# Altium schematic sheet 02 - Power CSI and Bank Power.SchDoc
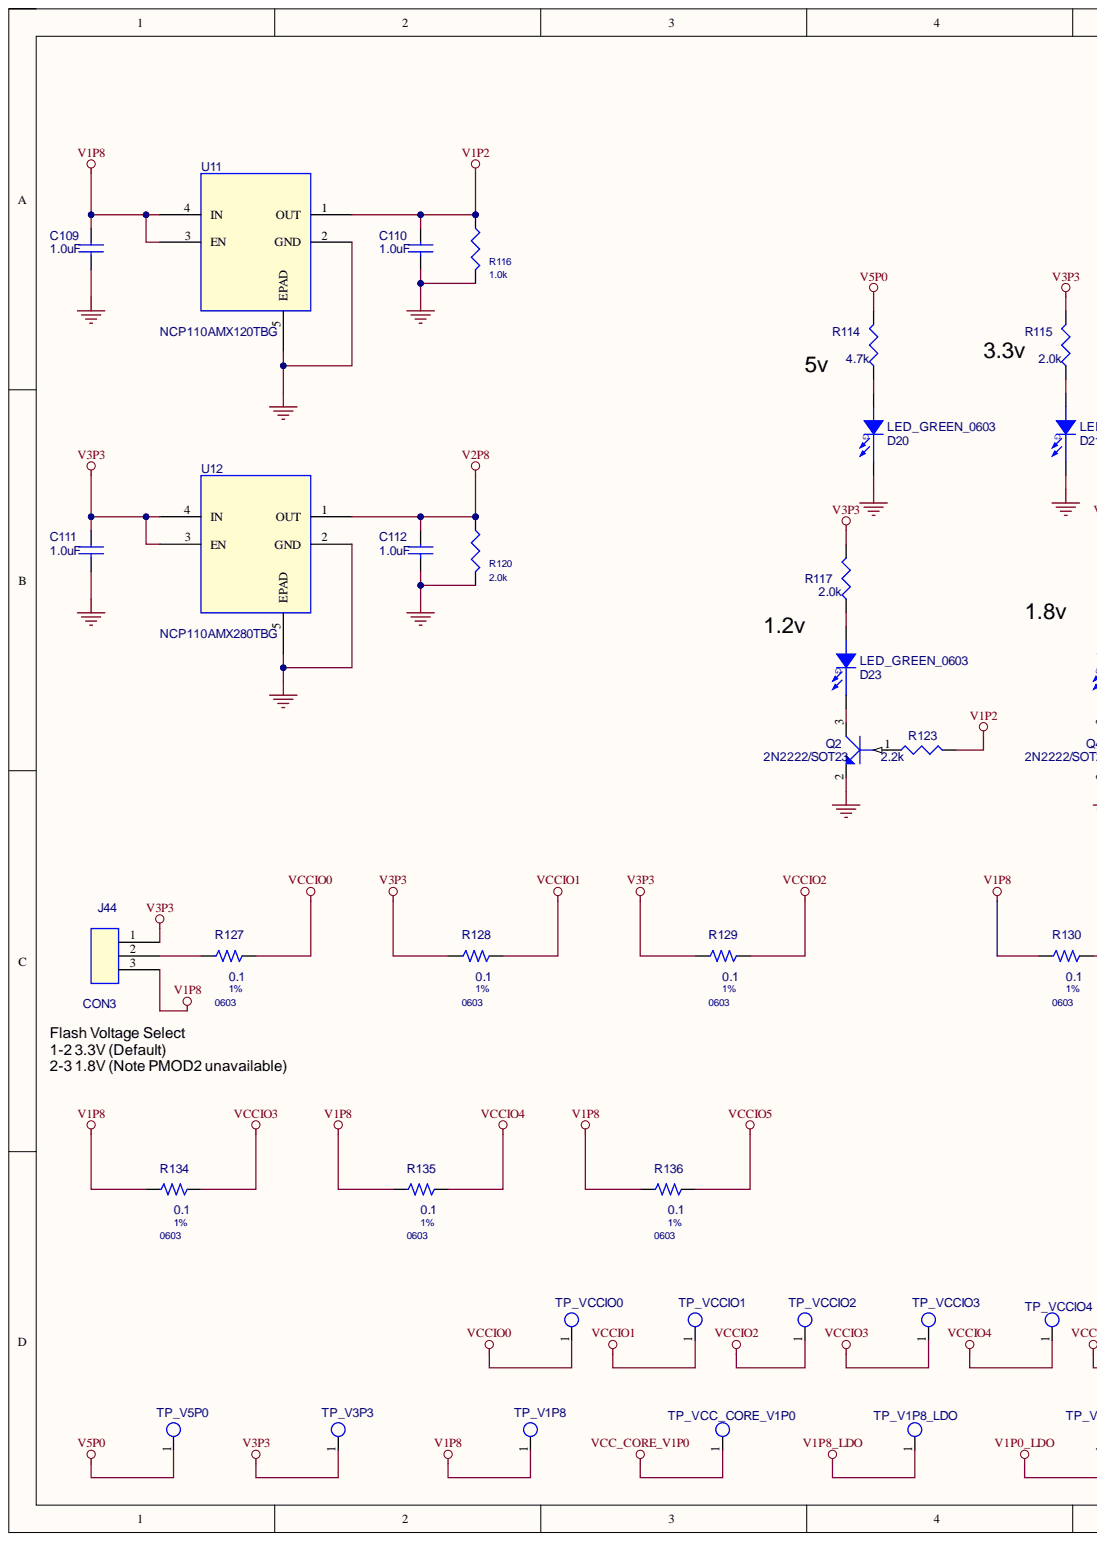
[diagram p0: 02 - Power CSI and Bank Power.SchDoc, left]
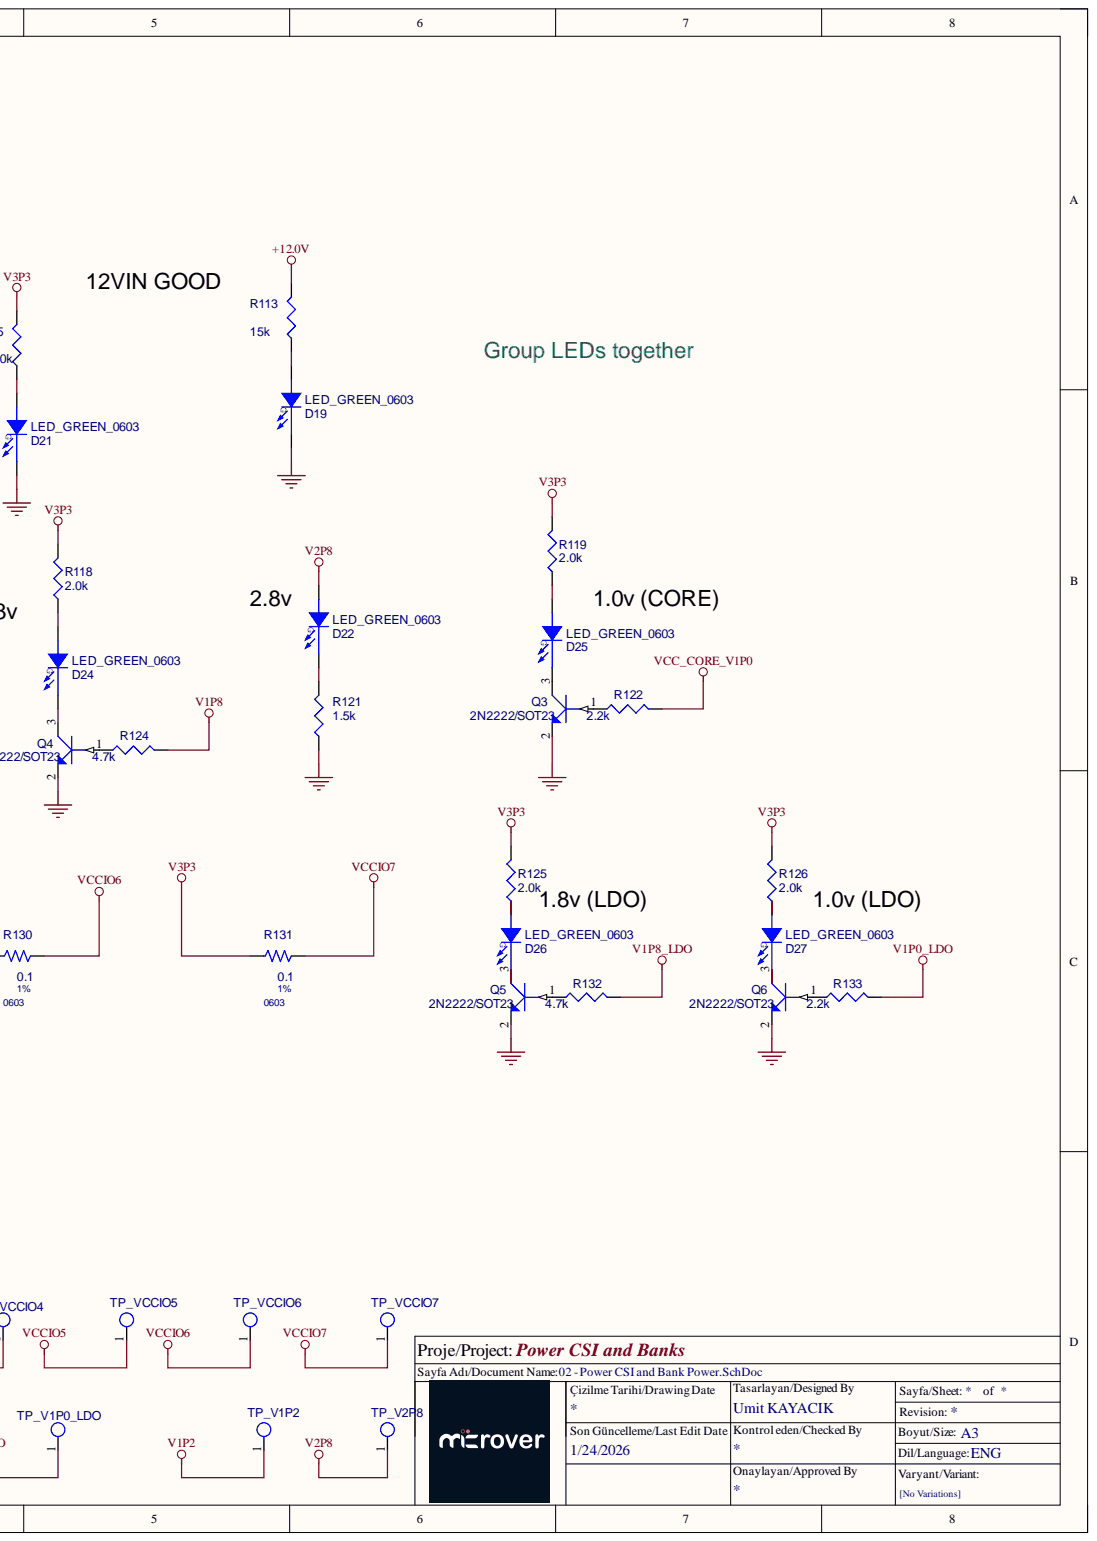
[diagram p1: 02 - Power CSI and Bank Power.SchDoc, right]

## Parts
C109  1.0uF  Part Reference: C109  [C0603]
C110  1.0uF  Part Reference: C110  [C0603]
C111  1.0uF  Part Reference: C111  [C0603]
C112  1.0uF  Part Reference: C112  [C0603]
D19  LED_GREEN_0603  Part Reference: D19  [APT1608]
D20  LED_GREEN_0603  Part Reference: D20  [APT1608]
D21  LED_GREEN_0603  Part Reference: D21  [APT1608]
D22  LED_GREEN_0603  Part Reference: D22  [APT1608]
D23  LED_GREEN_0603  Part Reference: D23  [APT1608]
D24  LED_GREEN_0603  Part Reference: D24  [APT1608]
D25  LED_GREEN_0603  Part Reference: D25  [APT1608]
D26  LED_GREEN_0603  Part Reference: D26  [APT1608]
D27  LED_GREEN_0603  Part Reference: D27  [APT1608]
J44  CON3  Part Reference: J44  [CON3]
Q2  2N2222/SOT23  Part Reference: Q2  [MMBT2222ALT-1]
Q3  2N2222/SOT23  Part Reference: Q3  [MMBT2222ALT-1]
Q4  2N2222/SOT23  Part Reference: Q4  [MMBT2222ALT-1]
Q5  2N2222/SOT23  Part Reference: Q5  [MMBT2222ALT-1]
Q6  2N2222/SOT23  Part Reference: Q6  [MMBT2222ALT-1]
R113  15k  Part Reference: R113  [RLP-103]
R114  4.7k  Part Reference: R114  [R0402]
R115  2.0k  Part Reference: R115  [R0402]
R116  1.0k  Part Reference: R116  [R0402]
R117  2.0k  Part Reference: R117  [R0402]
R118  2.0k  Part Reference: R118  [R0402]
R119  2.0k  Part Reference: R119  [R0402]
R120  2.0k  Part Reference: R120  [R0402]
R121  1.5k  Part Reference: R121  [R0402]
R122  2.2k  Part Reference: R122  [R0402]
R123  2.2k  Part Reference: R123  [R0402]
R124  4.7k  Part Reference: R124  [R0402]
R125  2.0k  Part Reference: R125  [R0402]
R126  2.0k  Part Reference: R126  [R0402]
R127  0.1  Part Reference: R127  Tollerance: 1%  [0603]
R128  0.1  Part Reference: R128  Tollerance: 1%  [0603]
R129  0.1  Part Reference: R129  Tollerance: 1%  [0603]
R130  0.1  Part Reference: R130  Tollerance: 1%  [0603]
R131  0.1  Part Reference: R131  Tollerance: 1%  [0603]
R132  4.7k  Part Reference: R132  [R0402]
R133  2.2k  Part Reference: R133  [R0402]
R134  0.1  Part Reference: R134  Tollerance: 1%  [0603]
R135  0.1  Part Reference: R135  Tollerance: 1%  [0603]
R136  0.1  Part Reference: R136  Tollerance: 1%  [0603]
TP_V1P0_LDO  Part Reference: TP_V1P0_LDO  [tp_s_40_63]  (hidden comment: TP_S_40_63)
TP_V1P2  Part Reference: TP_V1P2  [tp_s_40_63]  (hidden comment: TP_S_40_63)
TP_V1P8  Part Reference: TP_V1P8  [tp_s_40_63]  (hidden comment: TP_S_40_63)
TP_V1P8_LDO  Part Reference: TP_V1P8_LDO  [tp_s_40_63]  (hidden comment: TP_S_40_63)
TP_V2P8  Part Reference: TP_V2P8  [tp_s_40_63]  (hidden comment: TP_S_40_63)
TP_V3P3  Part Reference: TP_V3P3  [tp_s_40_63]  (hidden comment: TP_S_40_63)
TP_V5P0  Part Reference: TP_V5P0  [tp_s_40_63]  (hidden comment: TP_S_40_63)
TP_VCC_CORE_V1P0  Part Reference: TP_VCC_CORE_V1P0  [tp_s_40_63]  (hidden comment: TP_S_40_63)
TP_VCCIO0  Part Reference: TP_VCCIO0  [tp_s_40_63]  (hidden comment: TP_S_40_63)
TP_VCCIO1  Part Reference: TP_VCCIO1  [tp_s_40_63]  (hidden comment: TP_S_40_63)
TP_VCCIO2  Part Reference: TP_VCCIO2  [tp_s_40_63]  (hidden comment: TP_S_40_63)
TP_VCCIO3  Part Reference: TP_VCCIO3  [tp_s_40_63]  (hidden comment: TP_S_40_63)
TP_VCCIO4  Part Reference: TP_VCCIO4  [tp_s_40_63]  (hidden comment: TP_S_40_63)
TP_VCCIO5  Part Reference: TP_VCCIO5  [tp_s_40_63]  (hidden comment: TP_S_40_63)
TP_VCCIO6  Part Reference: TP_VCCIO6  [tp_s_40_63]  (hidden comment: TP_S_40_63)
TP_VCCIO7  Part Reference: TP_VCCIO7  [tp_s_40_63]  (hidden comment: TP_S_40_63)
U11  NCP110AMX120TBG  Part Reference: U11  [4XDFN_NCP110]
U12  NCP110AMX280TBG  Part Reference: U12  [4XDFN_NCP110]

## Nets
+12.0V: R113.2
GND: C109.2 C110.2 C111.2 C112.2 D19.1 D20.1 D21.1 Q2.2 Q3.2 Q4.2 Q5.2 Q6.2 R116.2 R120.2 R121.1 U11.2(GND) U11.5(EPAD) U12.2(GND) U12.5(EPAD)
V1P0_LDO: R133.2 TP_V1P0_LDO.1
V1P2: C110.1 R116.1 R123.2 TP_V1P2.1 U11.1(OUT)
V1P8: C109.1 J44.3 R124.2 R130.1 R134.1 R135.1 R136.1 TP_V1P8.1 U11.3(EN) U11.4(IN)
V1P8_LDO: R132.2 TP_V1P8_LDO.1
V2P8: C112.1 D22.2 R120.1 TP_V2P8.1 U12.1(OUT)
V3P3: C111.1 J44.1 R115.2 R117.2 R118.2 R119.2 R125.2 R126.2 R128.1 R129.1 R131.1 TP_V3P3.1 U12.3(EN) U12.4(IN)
V5P0: R114.2 TP_V5P0.1
VCC_CORE_V1P0: R122.2 TP_VCC_CORE_V1P0.1
VCCIO0: R127.2 TP_VCCIO0.1
VCCIO1: R128.2 TP_VCCIO1.1
VCCIO2: R129.2 TP_VCCIO2.1
VCCIO3: R134.2 TP_VCCIO3.1
VCCIO4: R135.2 TP_VCCIO4.1
VCCIO5: R136.2 TP_VCCIO5.1
VCCIO6: R130.2 TP_VCCIO6.1
VCCIO7: R131.2 TP_VCCIO7.1
NetD19_2: D19.2 R113.1
NetD20_2: D20.2 R114.1
NetD21_2: D21.2 R115.1
NetD22_1: D22.1 R121.2
NetD23_1: D23.1 Q2.3
NetD23_2: D23.2 R117.1
NetD24_1: D24.1 Q4.3
NetD24_2: D24.2 R118.1
NetD25_1: D25.1 Q3.3
NetD25_2: D25.2 R119.1
NetD26_1: D26.1 Q5.3
NetD26_2: D26.2 R125.1
NetD27_1: D27.1 Q6.3
NetD27_2: D27.2 R126.1
NetJ44_2: J44.2 R127.1
NetQ2_1: Q2.1 R123.1
NetQ3_1: Q3.1 R122.1
NetQ4_1: Q4.1 R124.1
NetQ5_1: Q5.1 R132.1
NetQ6_1: Q6.1 R133.1

## Library data of the parts
C109-C112 = CAP NP: CAP CER 10UF 10V 20% X6S 0603; Man. Part #: CL10X106MP8NRNC; Manufacturer: Samsung; Assy: -; Component Kind: Standard; Manufacturer Part Number: CL10X106MP8NRNC; MFG: Samsung; MFG P/N: CL10X106MP8NRNC; PCB Footprint: C0603; Value: 1.0uF
D19-D27 = LED-SMT1206_GREEN_1: Assy: -; Component Kind: Standard; PCB Footprint: APT1608; Value: LED_GREEN_0603
J44 = CON3: 3 Positions Header, Unshrouded Connector 0.100" (2.54mm) Through Hole Gold; Manufacturer: Wurth; PART_NUMBER: 61300311121; Assy: -; Component Kind: Standard; PCB Footprint: CON3; Value: CON3
Q2-Q6 = 2N2222: Assy: -; Component Kind: Standard; PCB Footprint: MMBT2222ALT-1; Value: 2N2222/SOT23
R113 = RES_0: Assy: -; Component Kind: Standard; PCB Footprint: RLP-103; Value: 15k
R114 = RES_0: RES SMD 2.2K OHM 1% 1/10W 0402; Manufacturer: Panasonic; Manufacturer Part Number: ERJ-2RKF2201X; Assy: -; Component Kind: Standard; PCB Footprint: R0402; Value: 4.7k
R115 R117-R119 R125 R126 = RES_0: RES SMD 1K OHM 1% 1/10W 0402; Manufacturer: Panasonic; Manufacturer Part Number: ERJ-2RKF1001X; Assy: -; Component Kind: Standard; PCB Footprint: R0402; Value: 2.0k
R116 R120 = RES_1K_1W16_0402_5P: RES SMD 1K OHM 1% 1/10W 0402; Manufacturer: Panasonic; Manufacturer Part Number: ERJ-2RKF1001X; DIST: FLAT; MAX_TEMP: RTMAX; MFG: Stackpole Electronics Inc; MFG P/N: RMCF0402JT1K00; TC1: 0; Total Area(sq-mm): 0.5; Unit Price (USD): 0.02; Wattage: 1/16W; Assy: -; Component Kind: Standard; Length(mm): 1; PCB Footprint: R0402; TC2: 0; Width(mm): 0.5
  R116: Reference: R116; Value: 1.0k
  R120: Reference: R120; Value: 2.0k
R121 = RES_0: RES SMD 750 OHM 5% 1/10W 0402; Manufacturer: Panasonic; Manufacturer Part Number: ERJ-2GEJ751X; Assy: -; Component Kind: Standard; PCB Footprint: R0402; Value: 1.5k
R122-R124 R132 R133 = RES_0: RES SMD 4.7K OHM 5% 1/10W 0402; Manufacturer: Panasonic; Manufacturer Part Number: ERJ-2GEJ472X; Assy: -; Component Kind: Standard; MFG: Panasonic; MFG P/N: ERJ-2GEJ472X; PCB Footprint: R0402
  R122 R123 R133: Value: 2.2k
  R124 R132: Value: 4.7k
R127-R131 R134-R136 = R_Dup2: COMPFIXED: NO; COMPGROUP: 0; COMPHEIGHT: 0.000; COMPLOC: [970.000,1160.000]; COMPROT: 180; COMPSIDE: TOP; DIST: FLAT; FPLIST: AX/RC05,SM/R_0603; MAX_TEMP: RTMAX; POWER: RMAX; PSpiceTemplate: R^@REFDES %1 %2 ?TOLERANCE|R^@REFDES| @VALUE  ?TOLERANCE|\n.model R^@REFDES RES R=1 DEV=@TOLERANCE%|; SLOPE: RSMAX; VOLTAGE: RVMAX; Assy: -; COMPKEY: NO; COMPLOCKED: NO; Component Kind: Standard; DECOUPLER: NO; MEMORY: NO; PCB Footprint: 0603; Simulation: R; Value: 0.1
TP_V1P0_LDO TP_V1P2 TP_V1P8 TP_V1P8_LDO TP_V2P8 TP_V3P3 TP_V5P0 TP_VCC_CORE_V1P0 TP_VCCIO0-TP_VCCIO7 = TP_S_40_63: Square test point, 40mil inner diameter, 63mil outer diameter; Assy: DNI; Class: IO; Component Kind: Standard; PCB Footprint: tp_s_40_63; Value: TP_S_40_63
U11 = NCP110AMX120TBG: Assy: -; Component Kind: Standard; PCB Footprint: 4XDFN_NCP110; Value: NCP110AMX120TBG
U12 = NCP110AMX280TBG: Assy: -; Component Kind: Standard; PCB Footprint: 4XDFN_NCP110; Value: NCP110AMX280TBG
